# Altium schematic sheet LPC55S69_MINI.SchDoc(LPC55S69_MINI) (continued)

## Library data of the parts
BTN1-BTN3 RESET1 = B3U-1100P: SWITCH TACTILE SPST-NO 0.05A 12V; Manufacturer: Omron Electronics Inc-EMC Div; Switch Travel: 0.15mm; Switch Function: Off-Mom; Outline: 3.00mm x 2.50mm; Operating Force: 153gf; Mechanical Life: 200,000 Cycles; Ingress Protection: Dust Proof; Illumination: Non-Illuminated; Contact Rating @ Voltage: 0.05A @ 12VDC; CategorySort: 700; Actuator Type: Standard; Actuator Orientation: Top Actuated; Actuator Height off PCB, from Pins: 1.60mm; Value: B3U-1100P; Termination Style: SMD (SMT) Tab; Supplier Part Number 1: SW1021CT-ND; Supplier 1: Digi-Key; Stock 1: 45170; RoHS: RoHS Compliant; Pricing 1: 1=1.25, 10=1.201, 25=1.1332, 50=0.997, 100=0.9064, 250=0.86108, 500=0.83842, 1000=0.65714 (USD); Packaging: Cut Tape (CT); Operating Temperature: -25°C ~ 70°C; Mounting Type: Surface Mount; Manufacturer Part Number: B3U-1100P; Library Name: Switches-N.SchLib; Illumination Voltage (Nominal): -; Illumination Type, Color: -; Features: Grounding Pin; ComponentLink1URL: http://www.components.omron.com/components/web/PDFLIB.nsf/0/2DD3893C7DD33E9E862572900079E9D6/$file/B3U_1110.pdf; ComponentLink1Description: http://www.components.omron.com/components/web/PDFLIB.nsf/0/2DD3893C7DD33E9E862572900079E9D6/$file/B3U_1110.pdf; Component Kind: Standard; Circuit: SPST-NO; Category: Switches; Actuator Material: -
C1 C7 = CGA4J1X7R0J106K125AC: CAP CER 10UF 6.3V X7R 0805; Manufacturer: TDK Corporation; Tolerance: ±10%; Substitution Notes: 10µF , 6.3V , ±10% , X7R , -55°C ~ 125°C , AEC-Q200 , 0805; Database Table Name: C0805$; Voltage - Rated: 6.3V; Value: 10µF; Thickness (Max): 1.45MM; Temperature Coefficient: X7R; Supplier Part Number 1: 445-6971-1-ND; Supplier 1: Digikey; Ratings: AEC-Q200; Package: 0805; Operating Temperature: -55°C ~ 125°C; Manufacturer Part Number: CGA4J1X7R0J106K125AC; Library Name: Capacitors - TDK- AEC-Q200.DbLib; Features: -; ComponentLink2URL: https://product.tdk.com/info/en/catalog/datasheets/mlcc_automotive_general_en.pdf; ComponentLink2Description: Datasheet (External); ComponentLink1URL: datasheets\TDK\mlcc_automotive_general_en.pdf; ComponentLink1Description: Datasheet (Internal); Component Kind: Standard; Category: Capacitors
C2 C4-C6 C8-C10 = CGA2B1X7R1C104K050BC: CAP CER 0.1UF 16V X7R 0402; Manufacturer: TDK Corporation; Tolerance: ±10%; Substitution Notes: 0.1µF , 16V , ±10% , X7R , -55°C ~ 125°C , AEC-Q200 , 0402; Database Table Name: C0402$; Voltage - Rated: 16V; Value: 0.1µF; Thickness (Max): 0.55MM; Temperature Coefficient: X7R; Supplier Part Number 1: 445-5613-1-ND; Supplier 1: Digikey; Ratings: AEC-Q200; Package: 0402; Operating Temperature: -55°C ~ 125°C; Manufacturer Part Number: CGA2B1X7R1C104K050BC; Library Name: Capacitors - TDK- AEC-Q200.DbLib; Features: -; ComponentLink2URL: https://product.tdk.com/info/en/catalog/datasheets/mlcc_automotive_general_en.pdf; ComponentLink2Description: Datasheet (External); ComponentLink1URL: datasheets\TDK\mlcc_automotive_general_en.pdf; ComponentLink1Description: Datasheet (Internal); Component Kind: Standard; Category: Capacitors
C3 = CGA2B2C0G1H470J050BA: CAP CER 47PF 50V C0G 0402; Manufacturer: TDK Corporation; Tolerance: ±5%; Temperature Coefficient: C0G, NP0; Substitution Notes: 47pF , 50V , ±5% , C0G, NP0 , -55°C ~ 125°C , AEC-Q200 , 0402; Database Table Name: C0402$; Voltage - Rated: 50V; Value: 47pF; Thickness (Max): 0.55MM; Supplier Part Number 1: 445-5592-1-ND; Supplier 1: Digikey; Ratings: AEC-Q200; Package: 0402; Operating Temperature: -55°C ~ 125°C; Manufacturer Part Number: CGA2B2C0G1H470J050BA; Library Name: Capacitors - TDK- AEC-Q200.DbLib; Features: -; ComponentLink2URL: https://product.tdk.com/info/en/catalog/datasheets/mlcc_automotive_general_en.pdf; ComponentLink2Description: Datasheet (External); ComponentLink1URL: datasheets\TDK\mlcc_automotive_general_en.pdf; ComponentLink1Description: Datasheet (Internal); Component Kind: Standard; Category: Capacitors
C11 C12 = CGA2B2C0G1H180J050BA: CAP CER 18PF 50V C0G 0402; Manufacturer: TDK Corporation; Tolerance: ±5%; Temperature Coefficient: C0G, NP0; Substitution Notes: 18pF , 50V , ±5% , C0G, NP0 , -55°C ~ 125°C , AEC-Q200 , 0402; Database Table Name: C0402$; Voltage - Rated: 50V; Value: 18pF; Thickness (Max): 0.55MM; Supplier Part Number 1: 445-5587-1-ND; Supplier 1: Digikey; Ratings: AEC-Q200; Package: 0402; Operating Temperature: -55°C ~ 125°C; Manufacturer Part Number: CGA2B2C0G1H180J050BA; Library Name: Capacitors - TDK- AEC-Q200.DbLib; Features: -; ComponentLink2URL: https://product.tdk.com/info/en/catalog/datasheets/mlcc_automotive_general_en.pdf; ComponentLink2Description: Datasheet (External); ComponentLink1URL: datasheets\TDK\mlcc_automotive_general_en.pdf; ComponentLink1Description: Datasheet (Internal); Component Kind: Standard; Category: Capacitors
D1 = BAT760-7: DIODE SCHOTTKY 30V 1A SOD323; Manufacturer: Diodes Inc; Voltage - Forward (Vf) (Max) @ If: 550mV @ 1A; Speed: Fast Recovery =< 500ns- > 200mA (Io); Num Pins: 2; Diode Suffix: 1A_2C; Database Table Name: Schottky$; Current - Reverse Leakage @ Vr: 50µA @ 15V; CategorySort: 400; Capacitance @ Vr- F: 25pF @ 5V- 1MHz; Voltage - DC Reverse (Vr) (Max): 30V; Value: BAT760-7; Supplier Part Number 1: BAT760DICT-ND; Supplier Device Package: SOD-323; Supplier 1: Digi-Key; SortValue: BAT760-7; Reverse Recovery Time (trr): -; Packaging: Cut Tape (CT); Package: SC-76- SOD-323; Mounting Type: Surface Mount; Manufacturer Part Number: BAT760-7; Library Name: Diodes.DbLib; Diode Type: Schottky; Current - Average Rectified (Io): 1A; ComponentLink2URL: http://www.diodes.com/datasheets/ds30498.pdf; ComponentLink2Description: External Datasheet; ComponentLink1URL: http://www.diodes.com/datasheets/ds30498.pdf; ComponentLink1Description: Internal Datasheet; Component Kind: Standard; Category: Diodes
J1 = 10103594-0001LF: CONN RCPT STD MICRO USB TYPE B; Manufacturer: FCI; Specifications: USB 2.0; Number of Contacts: 5; Notes: Similar to; Micro USB B: Value; Gender: Receptacle; Connector Type: USB - micro B; Value: USB Micro B; Supplier Part Number 1: 609-4050-1-ND; Supplier 1: Digi-Key; Stock 1: 23288; RoHS: RoHS Compliant; Pricing 1: 1=1.1, 10=0.768, 100=0.517, 250=0.43912, 500=0.41716 (USD); Packaging: Cut Tape (CT); Mounting Type: Surface Mount, Right Angle, Horizontal; Manufacturer Part Number: 10103594-0001LF; Library Name: eConnector_USB.SchLib; Ingress Protection: -; Features: Shielded, Solder Retention; ComponentLink1URL: http://portal.fciconnect.com/res/en/pdffiles/doc_search/10103594.pdf; ComponentLink1Description: http://portal.fciconnect.com/res/en/pdffiles/doc_search/10103594.pdf; Component Kind: Standard; Category: Connectors, Interconnects
J3 = CORTEX-DEBUG: SAMTEC - FTSH-105-01-F-DV - .050 X .050; Value: FTSH-105-01-F-DV; Supplier Part Number 1: 01R3399; Supplier 1: Newark; Stock 1: 0; Pricing 1: 1=0.974, 25000=0.835, 50000=0.774 (USD); Manufacturer Part Number: FTSH-105-01-F-DV; Manufacturer: SAMTEC; Library Name: eConnector.SchLib; Component Kind: Standard; Category: Connectors
J4 = TC2030‐CTL‐NL: Tag Connect Pads - No latching Clips - ARM; Value: TC2030‐CTL‐NL; Library Name: eConnector.SchLib
L1 L3 L4 = (no symbol name): FERRITE BEAD 220 OHM 0402 1LN; Manufacturer: Taiyo Yuden; Number of Lines: 1; Impedance @ Frequency: 220 Ohm @ 100MHz; Filter Type: Power Line; DC Resistance (DCR) (Max): 150 mOhm; Current Rating (Max): 1A; Value: BKP1005EM221-T; Supplier Part Number 1: 587-3290-2-ND; Supplier 1: Digi-Key; Stock 1: 60000; Size / Dimension: 0.039" L x 0.022" W (1.00mm x 0.55mm); RoHS: RoHS Compliant; Ratings: -; Pricing 1: 10000=0.0145, 20000=0.014, 30000=0.013, 50000=0.01285 (USD); Packaging: Tape & Reel (TR); Package / Case: 0402 (1005 Metric); Operating Temperature: -55°C ~ 85°C; Mounting Type: Surface Mount; Manufacturer Part Number: BKP1005EM221-T; Library Name: eInductor.SchLib; Height (Max): 0.022" (0.55mm); ComponentLink1URL: http://ds.yuden.co.jp/TYCOMPAS/ut/detail.do?productNo=BKP1005EM221-T&fileName=BKP1005EM221-T_SS&mode=specSheetDownload; ComponentLink1Description: http://ds.yuden.co.jp/TYCOMPAS/ut/detail.do?productNo=BKP1005EM221-T&fileName=BKP1005EM221-T_SS&mode=specSheetDownload; Component Kind: Standard; Category: Filters
L2 = (no symbol name): FIXED IND 4.7UH 520MA 650 MOHM; Manufacturer: Taiyo Yuden; Tolerance: 20%; Test Frequency: 7.96MHz; Shielding: Unshielded; Self Resonant Frequency: 45MHz; Saturation Current: 360mA; DC Resistance (DCR): 650mR; Core Material: Ferrite; Width: 0.049inch; Value: CBC2012T4R7M; Termination: SMD/SMT; Supplier Part Number 1: 587-1602-1-ND; Supplier 1: Digi-Key; Stock 1: 7720; RoHS: Yes; REACH SVHC: No SVHC; Pricing 1: 1=0.24000, 10=0.21600, 100=0.14860, 500=0.12702, 1000=0.09729 (USD); Packaging: Tape and Reel; Package Quantity: 3000; Number of Pins: 2; Mount: Surface Mount; Min Operating Temperature: -40°C; Max Operating Temperature: 105°C; Max DC Current: 520mA; Manufacturer Part Number: CBC2012T4R7M; Library Name: eInductor.SchLib; Length: 0.079inch; Lead Free: Exempt; Inductance Tolerance: 20%; Inductance: 4.7uH; Height - Seated (Max): 0.057inch; Height: 1.25mm; Halogen Free: Halogen Free; Current Rating: 520mA; Composition: Wirewound; ComponentLink4URL: https://datasheet.ciiva.com/8001/wound02-e-8001849.pdf?src-supplier=Element14; ComponentLink4Description: https://datasheet.ciiva.com/8001/wound02-e-8001849.pdf?src-supplier=Element14; ComponentLink3URL: https://datasheet.ciiva.com/8505/cbc2012t4r7m-ss-8505656.pdf?src-supplier=Avnet; ComponentLink3Description: https://datasheet.ciiva.com/8505/cbc2012t4r7m-ss-8505656.pdf?src-supplier=Avnet; ComponentLink2URL: https://datasheet.ciiva.com/1040/cbc2012t4r7m-ss-1040456.pdf?src-supplier=Digi-Key; ComponentLink2Description: https://datasheet.ciiva.com/1040/cbc2012t4r7m-ss-1040456.pdf?src-supplier=Digi-Key; ComponentLink1URL: https://datasheet.ciiva.com/15981/214cbc2012t4r7m-15981637.pdf?src-supplier=Onlinecomponents.com; ComponentLink1Description: https://datasheet.ciiva.com/15981/214cbc2012t4r7m-15981637.pdf?src-supplier=Onlinecomponents.com; Component Kind: Standard; Case Code (Metric): 2012; Case Code (Imperial): 0805; Case/Package: 0805
Q1 = FDV303N: MOSFET N-CH 25V 680MA SOT-23; Source Part Number: FDV303NCT-ND; Manufacturer: Fairchild Semiconductor; Vgs(th) (Max) @ Id: 1.5V @ 250µA; Rds On (Max) @ Id, Vgs: 450 mOhm @ 500mA, 4.5V; Power - Max: 350mW; Input Capacitance (Ciss) @ Vds: 50pF @ 10V; Gate Charge (Qg) @ Vgs: 2.3nC @ 4.5V; FET Type: MOSFET N-Channel, Metal Oxide; FET Feature: Logic Level Gate; Value: FDV303N; Supplier Part Number 1: FDV303NCT-ND; Supplier Device Package: SOT-23; Supplier 1: Digi-Key; Stock 1: 0; RoHS: RoHS Compliant; Pricing 1: 1=0.49, 10=0.347, 100=0.231, 250=0.16632, 500=0.13398, 750=0.11551, 1000=0.10395, 2000=0.0924 (USD); Packaging: Cut Tape (CT); Package / Case: SOT-23-3, TO-236-3, Micro3™, SSD3, SST3; Mounting Type: Surface Mount; Manufacturer Part Number: FDV303N; Library Name: eTransistor.SchLib; Drain to Source Voltage (Vdss): 25V; Current - Continuous Drain (Id) @ 25° C: 680mA; ComponentLink1URL: http://www.fairchildsemi.com/ds/FD/FDV303N.pdf; ComponentLink1Description: http://www.fairchildsemi.com/ds/FD/FDV303N.pdf; Component Kind: Standard; Category: Discrete Semiconductor Products; Source: Digikey
R1 R5-R9 = ERJ-2RKF1002X: RES 10K OHM 1/10W 1% 0402 SMD; Manufacturer: Panasonic Electronic Components; Temperature Coefficient: ±100ppm/°C; Substitution Notes: 10k , ±1% , 0402 , AEC-Q200; Power (Watts): 0.1W- 1/10W; Package Power: 0402  (0.1W); Maximum Working Voltage: 31.6V; Maximum Overload Voltage: 100; Limiting Element Voltage: 50; Database Table Name: 1%$; Value: 10k; Tolerance: ±1%; Supplier Part Number 1: P10.0KLCT-ND; Supplier Package: 0402; Supplier 1: Digi-Key; Sort Value Package: 402_10000; Sort Value: 10000; Size / Dimension: 0.039" L x 0.020" W (1.00mm x 0.50mm); Resistance (Ohms): 10k; Ratings: AEC-Q200; Packaging: Cut Tape (CT); Package: 0402 (1005 Metric); Number of Terminations: 2; Manufacturer Part Number: ERJ-2RKF1002X; Library Name: Resistors - Panasonic - AEC-Q200.DbLib; Height: 0.40MM; Features: -; Composition: Thick Film; ComponentLink2URL: http://industrial.panasonic.com/www-data/pdf/AOA0000/AOA0000CE2.pdf; ComponentLink2Description: External Datasheet; ComponentLink1URL: datasheets\Panasonic Electronic Components\AOA0000CE2.pdf; ComponentLink1Description: Internal Datasheet; Component Kind: Standard; Category: Resistors
R2 = ERJ-2RKF1912X: RES 19.1K OHM 1/10W 1% 0402 SMD; Manufacturer: Panasonic Electronic Components; Temperature Coefficient: ±100ppm/°C; Substitution Notes: 19.1k , ±1% , 0402 , AEC-Q200; Power (Watts): 0.1W- 1/10W; Package Power: 0402  (0.1W); Maximum Working Voltage: 43.7V; Maximum Overload Voltage: 100; Limiting Element Voltage: 50; Database Table Name: 1%$; Value: 19.1k; Tolerance: ±1%; Supplier Part Number 1: P19.1KLCT-ND; Supplier Package: 0402; Supplier 1: Digi-Key; Sort Value Package: 402_19100; Sort Value: 19100; Size / Dimension: 0.039" L x 0.020" W (1.00mm x 0.50mm); Resistance (Ohms): 19.1k; Ratings: AEC-Q200; Packaging: Cut Tape (CT); Package: 0402 (1005 Metric); Number of Terminations: 2; Manufacturer Part Number: ERJ-2RKF1912X; Library Name: Resistors - Panasonic - AEC-Q200.DbLib; Height: 0.40MM; Features: -; Composition: Thick Film; ComponentLink2URL: http://industrial.panasonic.com/www-data/pdf/AOA0000/AOA0000CE2.pdf; ComponentLink2Description: External Datasheet; ComponentLink1URL: datasheets\Panasonic Electronic Components\AOA0000CE2.pdf; ComponentLink1Description: Internal Datasheet; Component Kind: Standard; Category: Resistors
R3 R4 = ERJ-3EKF33R0V: RES 33 OHM 1/10W 1% 0603 SMD; Manufacturer: Panasonic Electronic Components; Temperature Coefficient: ±100ppm/°C; Substitution Notes: 33 , ±1% , 0603 , AEC-Q200; Power (Watts): 0.1W- 1/10W; Package Power: 0603  (0.1W); Maximum Working Voltage: 1.8V; Maximum Overload Voltage: 150; Limiting Element Voltage: 75; Database Table Name: 1%$; Value: 33; Tolerance: ±1%; Supplier Part Number 1: P33.0HCT-ND; Supplier Package: 0603; Supplier 1: Digi-Key; Sort Value Package: 603_33; Sort Value: 33; Size / Dimension: 0.063" L x 0.031" W (1.60mm x 0.80mm); Resistance (Ohms): 33; Ratings: AEC-Q200; Packaging: Cut Tape (CT); Package: 0603 (1608 Metric); Number of Terminations: 2; Manufacturer Part Number: ERJ-3EKF33R0V; Library Name: Resistors - Panasonic - AEC-Q200.DbLib; Height: 0.55MM; Features: -; Composition: Thick Film; ComponentLink2URL: http://industrial.panasonic.com/www-data/pdf/AOA0000/AOA0000CE2.pdf; ComponentLink2Description: External Datasheet; ComponentLink1URL: datasheets\Panasonic Electronic Components\AOA0000CE2.pdf; ComponentLink1Description: Internal Datasheet; Component Kind: Standard; Category: Resistors
U1 = (no symbol name): FLORA LI-PO BATTERY 3.7V 150MAH; Manufacturer: Adafruit Industries; Width: 1.75inch; Weight: 0.01lb; Value: 1317; Supplier Part Number 1: 1528-1839-ND; Supplier 1: Digi-Key; Stock 1: 333; RoHS: No; Pricing 1: 1=5.95000 (USD); Mount: Cable; Manufacturer Part Number: 1317; Library Name: eBattery.SchLib; Length: 2.5inch; Height: 0.5inch; ComponentLink2URL: https://cdn-shop.adafruit.com/product-files/1317/C1515_-_Li-Polymer_402025_150mAh_3.7V_with_PCM.pdf?src-supplier=Digi-Key; ComponentLink2Description: https://cdn-shop.adafruit.com/product-files/1317/C1515_-_Li-Polymer_402025_150mAh_3.7V_with_PCM.pdf?src-supplier=Digi-Key; ComponentLink1URL: http://www.mouser.com/ds/2/737/C1515_-_Li-Polymer_402025_150mAh_3.7V_with_PCM-932829.pdf?src-supplier=Mouser; ComponentLink1Description: http://www.mouser.com/ds/2/737/C1515_-_Li-Polymer_402025_150mAh_3.7V_with_PCM-932829.pdf?src-supplier=Mouser; Component Kind: Standard
U2 = (no symbol name): Charge Controller Li-Ion/Li-Pol 15mA to 500mA 4.2V 5-Pin SOT-23 T/R; Manufacturer: Microchip; Value: MCP73832T-2ATI/OT; Supplier Part Number 1: MCP73832T-2ATI/OTCT-ND; Supplier 1: Digi-Key; Stock: 3196; RoHS: Yes; Pricing: 1=0.56, 25=0.47, 100=0.42 (USD); Manufacturer Part Number: MCP73832T-2ATI/OT; Library Name: eIC.SchLib; ComponentLink6URL: https://datasheet.ciiva.com/17299/21984e-17299342.pdf?src-supplier=Avnet+Europe; ComponentLink6Description: https://datasheet.ciiva.com/17299/21984e-17299342.pdf?src-supplier=Avnet+Europe; ComponentLink5URL: https://datasheet.ciiva.com/1932/21984e-1932957.pdf?src-supplier=Digi-Key; ComponentLink5Description: https://datasheet.ciiva.com/1932/21984e-1932957.pdf?src-supplier=Digi-Key; ComponentLink4URL: https://datasheet.ciiva.com/1932/21984e-1932956.pdf?src-supplier=Digi-Key; ComponentLink4Description: https://datasheet.ciiva.com/1932/21984e-1932956.pdf?src-supplier=Digi-Key; ComponentLink3URL: https://datasheet.ciiva.com/1932/21984e-1932955.pdf?src-supplier=Digi-Key; ComponentLink3Description: https://datasheet.ciiva.com/1932/21984e-1932955.pdf?src-supplier=Digi-Key; ComponentLink2URL: https://datasheet.ciiva.com/15115/getdatasheetpartid-574102-15115383.pdf?src-supplier=Verical; ComponentLink2Description: https://datasheet.ciiva.com/15115/getdatasheetpartid-574102-15115383.pdf?src-supplier=Verical; ComponentLink1URL: https://datasheet.ciiva.com/1137/630369-1137827.pdf?src-supplier=Element14; ComponentLink1Description: https://datasheet.ciiva.com/1137/630369-1137827.pdf?src-supplier=Element14; Component Kind: Standard
U3 = (no symbol name): IC REG LINEAR 3.3V 300MA SOT23-5; Manufacturer: Microchip; Quiescent Current: 50uA; Power Dissipation: 250mW; Polarity: Positive; Output Voltage Accuracy: 2%; Output Type: Fixed; Number of Outputs: 1; Min Input Voltage: 2V; Max Input Voltage: 10V; Input Bias Current: 25uA; Dropout Voltage: 200mV; Width: 0.071inch; Value: MCP1802T-3302I/OT; Supplier Part Number 1: MCP1802T-3302I/OTCT-ND; Supplier 1: Digi-Key; Stock 1: 3179; RoHS: Yes; REACH SVHC: No SVHC; Radiation Hardening: No; Pricing 1: 1=0.53000, 25=0.44000, 100=0.40000 (USD); Packaging: Tape and Reel; Package Quantity: 3000; Output Voltage: 3.3V; Output Current: 300mA; Number of Pins: 5; Nominal Output Voltage: 3.3V; Mount: Surface Mount; Min Output Voltage: 3.3V; Min Operating Temperature: -40°C; Max Power Dissipation: 250mW; Max Output Current: 300mA; Max Operating Temperature: 85°C; Manufacturer Part Number: MCP1802T-3302I/OT; Library Name: eIC_Regulator.SchLib; Length: 0.122inch; Lead Free: Lead Free; Height: 0.051inch; ComponentLink9URL: https://datasheet.ciiva.com/8239/jp531342-8239437.pdf?src-supplier=Avnet; ComponentLink9Description: https://datasheet.ciiva.com/8239/jp531342-8239437.pdf?src-supplier=Avnet; ComponentLink8URL: https://datasheet.ciiva.com/46395/808935-46395672.pdf?src-supplier=Element14; ComponentLink8Description: https://datasheet.ciiva.com/46395/808935-46395672.pdf?src-supplier=Element14; ComponentLink7URL: https://datasheet.ciiva.com/14562/70048283-14562246.pdf?src-supplier=Allied+Electronics; ComponentLink7Description: https://datasheet.ciiva.com/14562/70048283-14562246.pdf?src-supplier=Allied+Electronics; ComponentLink6URL: https://datasheet.ciiva.com/2040/22053c-2040530.pdf?src-supplier=Digi-Key; ComponentLink6Description: https://datasheet.ciiva.com/2040/22053c-2040530.pdf?src-supplier=Digi-Key; ComponentLink5URL: https://datasheet.ciiva.com/2040/22053c-2040529.pdf?src-supplier=Digi-Key; ComponentLink5Description: https://datasheet.ciiva.com/2040/22053c-2040529.pdf?src-supplier=Digi-Key; ComponentLink4URL: https://datasheet.ciiva.com/15200/getdatasheetpartid-626522-15200301.pdf?src-supplier=Verical; ComponentLink4Description: https://datasheet.ciiva.com/15200/getdatasheetpartid-626522-15200301.pdf?src-supplier=Verical; ComponentLink3URL: https://datasheet.ciiva.com/16454/filehandler-aspxddocname-en531342-16454309.pdf?src-supplier=Future+Electronics; ComponentLink3Description: https://datasheet.ciiva.com/16454/filehandler-aspxddocname-en531342-16454309.pdf?src-supplier=Future+Electronics; ComponentLink2URL: https://datasheet.ciiva.com/2040/22053c-2040531.pdf?src-supplier=Digi-Key; ComponentLink2Description: https://datasheet.ciiva.com/2040/22053c-2040531.pdf?src-supplier=Digi-Key; ComponentLink1URL: https://datasheet.ciiva.com/41458/001085944-da-01-en-ic-reg-ld-mcp1802t-3302i-ot-sot-23-5-mcp-41458834.pdf?src-supplier=Conrad; ComponentLink1Description: https://datasheet.ciiva.com/41458/001085944-da-01-en-ic-reg-ld-mcp1802t-3302i-ot-sot-23-5-mcp-41458834.pdf?src-supplier=Conrad; Component Kind: Standard; Case/Package: SOT-23; Accuracy: 2%
U4 = PRTR5V0U2X,215: TVS DIODE 5.5VWM SOT143B; Manufacturer: NXP Semiconductors; Voltage - Reverse Standoff (Typ): 5.5V (Max); Voltage - Breakdown (Min): 6V; Power Line Protection: Yes; Capacitance @ Frequency: 1pF @ 1MHz; Bidirectional Channels: 2; Voltage - Clamping (Max) @ Ipp: -; Value: PRTR5V0U2X,215; Unidirectional Channels: -; Type: Steering (Rail to Rail); Supplier Part Number 1: 568-4140-1-ND; Supplier Device Package: SOT-143B; Supplier 1: Digi-Key; Stock: 11734; RoHS: RoHS Compliant; Pricing: 1=0.57, 10=0.477, 25=0.418, 100=0.3578, 250=0.31036, 500=0.26286, 1000=0.20265 (USD); Power - Peak Pulse: -; Packaging: Cut Tape (CT); Package / Case: TO-253-4, TO-253AA; Operating Temperature: -40°C ~ 85°C (TA); Mounting Type: Surface Mount; Manufacturer Part Number: PRTR5V0U2X,215; Library Name: eDiode.SchLib; Current - Peak Pulse (10/1000µs): -; ComponentLink1URL: http://www.nxp.com/documents/data_sheet/PRTR5V0U2X.pdf; ComponentLink1Description: http://www.nxp.com/documents/data_sheet/PRTR5V0U2X.pdf; Component Kind: Standard; Category: Circuit Protection; Applications: General Purpose
U5 = (no symbol name): IC MCU 100MHZ CORTEX M33 DUAL; Manufacturer: NXP Semiconductors; Value: LPC55S69JEV98K; Supplier Part Number 1: 771-LPC55S69JEV98K; Supplier 1: Mouser; Stock: 2365; RoHS: No; Pricing: 1=6.94000, 10=6.27000, 25=5.98000, 100=5.19000, 250=4.96000, 500=4.52000 (USD); Manufacturer Part Number: LPC55S69JEV98K; Library Name: eIC.SchLib; Component Kind: Standard
U6 = (no symbol name): 20Hz ~ 10kHz Digital, I²S Microphone MEMS (Silicon) 1.8V Omnidirectional (-26dB ±3dB @ 94dB SPL) Solder Pads; Manufacturer: Knowles Acoustics; Shape: Rectangular; Operating Supply Current: 600uA; Width: 2.65mm; Voltage Rating: 1.8V; Value: SPH0645LM4H-B; Termination: Solder; Supplier Part Number 1: 423-1405-1-ND; Supplier 1: Digi-Key; Stock 1: 13538; Pricing 1: 1=2.97, 10=2.357, 25=2.1448, 50=2.0076, 100=1.8704, 250=1.70832, 500=1.58364, 1000=1.5587 (USD); Packaging: Tape and Reel; Output Type: Digital; Manufacturer Part Number: SPH0645LM4H-B; Library Name: eAudio.SchLib; Length: 3.5mm; Height: 1.08mm; Direction: Omnidirectional; ComponentLink2URL: https://media.digikey.com/pdf/Data%20Sheets/Knowles%20Acoustics%20PDFs/SPH0645LM4H-B.pdf; ComponentLink2Description: https://media.digikey.com/pdf/Data%20Sheets/Knowles%20Acoustics%20PDFs/SPH0645LM4H-B.pdf; ComponentLink1URL: http://www.knowles.com/eng/content/download/5988/105771/version/4/file/SPH0645LM4H-BRevB.PDF; ComponentLink1Description: http://www.knowles.com/eng/content/download/5988/105771/version/4/file/SPH0645LM4H-BRevB.PDF; Component Kind: Standard
U7 = (no symbol name): 1.54" 240x240 IPS Display; Manufacturer: Buy Display; Value: ER-TFT1.54-1; Manufacturer Part Number: ER-TFT1.54-1; Library Name: eDisplay.SchLib; Component Kind: Standard
U9 = (no symbol name): Crystal 16MHz ±20ppm (Tol) ±50ppm (Stability) 18pF FUND 50Ohm 2-Pin CSMD T/R; Manufacturer Part Number: ABM3-16.000MHZ-B2-T; Manufacturer: Abracon; Series Resistance: 60R; ESR (Equivalent Series Resistance): 40R; Width: 3.2mm; Value: 16MHz; Tolerance: 20ppm; Termination: SMD/SMT; Supplier Part Number 1: 535-9103-1-ND; Supplier 1: Digi-Key; Stock: 9690; RoHS: Yes; REACH SVHC: Yes; Pricing: 1=0.66000, 10=0.55300, 50=0.49780, 100=0.44260, 500=0.42044 (USD); Packaging: Tape and Reel; Package Quantity: 1000; Number of Pins: 2; Mount: Surface Mount; Min Operating Temperature: -20°C; Max Operating Temperature: 70°C; Load Capacitance: 18pF; Library Name: eCrystal.SchLib; Length: 5mm; Lead Free: Lead Free; Height: 0.051inch; Frequency Tolerance: 20ppm; Frequency Stability: 50ppm; Frequency: 16MHz; Depth: 3.2mm; Composition: Ceramic; ComponentLink6URL: https://datasheet.ciiva.com/16209/abm3-16209965.pdf?src-supplier=Future+Electronics; ComponentLink6Description: https://datasheet.ciiva.com/16209/abm3-16209965.pdf?src-supplier=Future+Electronics; ComponentLink5URL: https://datasheet.ciiva.com/855/abm3-855739.pdf?src-supplier=Digi-Key; ComponentLink5Description: https://datasheet.ciiva.com/855/abm3-855739.pdf?src-supplier=Digi-Key; ComponentLink4URL: https://datasheet.ciiva.com/855/abm3-855738.pdf?src-supplier=Digi-Key; ComponentLink4Description: https://datasheet.ciiva.com/855/abm3-855738.pdf?src-supplier=Digi-Key; ComponentLink3URL: https://datasheet.ciiva.com/855/abm3-855737.pdf?src-supplier=Digi-Key; ComponentLink3Description: https://datasheet.ciiva.com/855/abm3-855737.pdf?src-supplier=Digi-Key; ComponentLink2URL: https://datasheet.ciiva.com/4945/abm3-47699-4945240.pdf?src-supplier=Mouser; ComponentLink2Description: https://datasheet.ciiva.com/4945/abm3-47699-4945240.pdf?src-supplier=Mouser; ComponentLink1URL: https://datasheet.ciiva.com/15116/getdatasheetpartid-719852-15116752.pdf?src-supplier=Verical; ComponentLink1Description: https://datasheet.ciiva.com/15116/getdatasheetpartid-719852-15116752.pdf?src-supplier=Verical; Component Kind: Standard; Category: Crystals; Case/Package: CSMD; Accuracy: 20ppm
U10 = OPA340: Source Part Number: OPA340NACT-ND; Manufacturer Part Number: OPA340NA/250; Manufacturer: Texas Instruments; ROHS Certificate: ROHS-TI.pdf; Library Name: eOPAMP.SchLib; Description: IC SINGLE SUPPLY OPAMP SOT-23-5; Component Kind: Standard; Source: Digikey
P1-P22 = (no symbol name): Library Name: eConnector.SchLib
